# Altium schematic sheet 6_A9912FH-PCB2-BRIDGE_SR_MCU BOARD_V1.SchDoc("6_A9912FH-PCB2-BRIDGE_SR_MCU BOARD_V1")
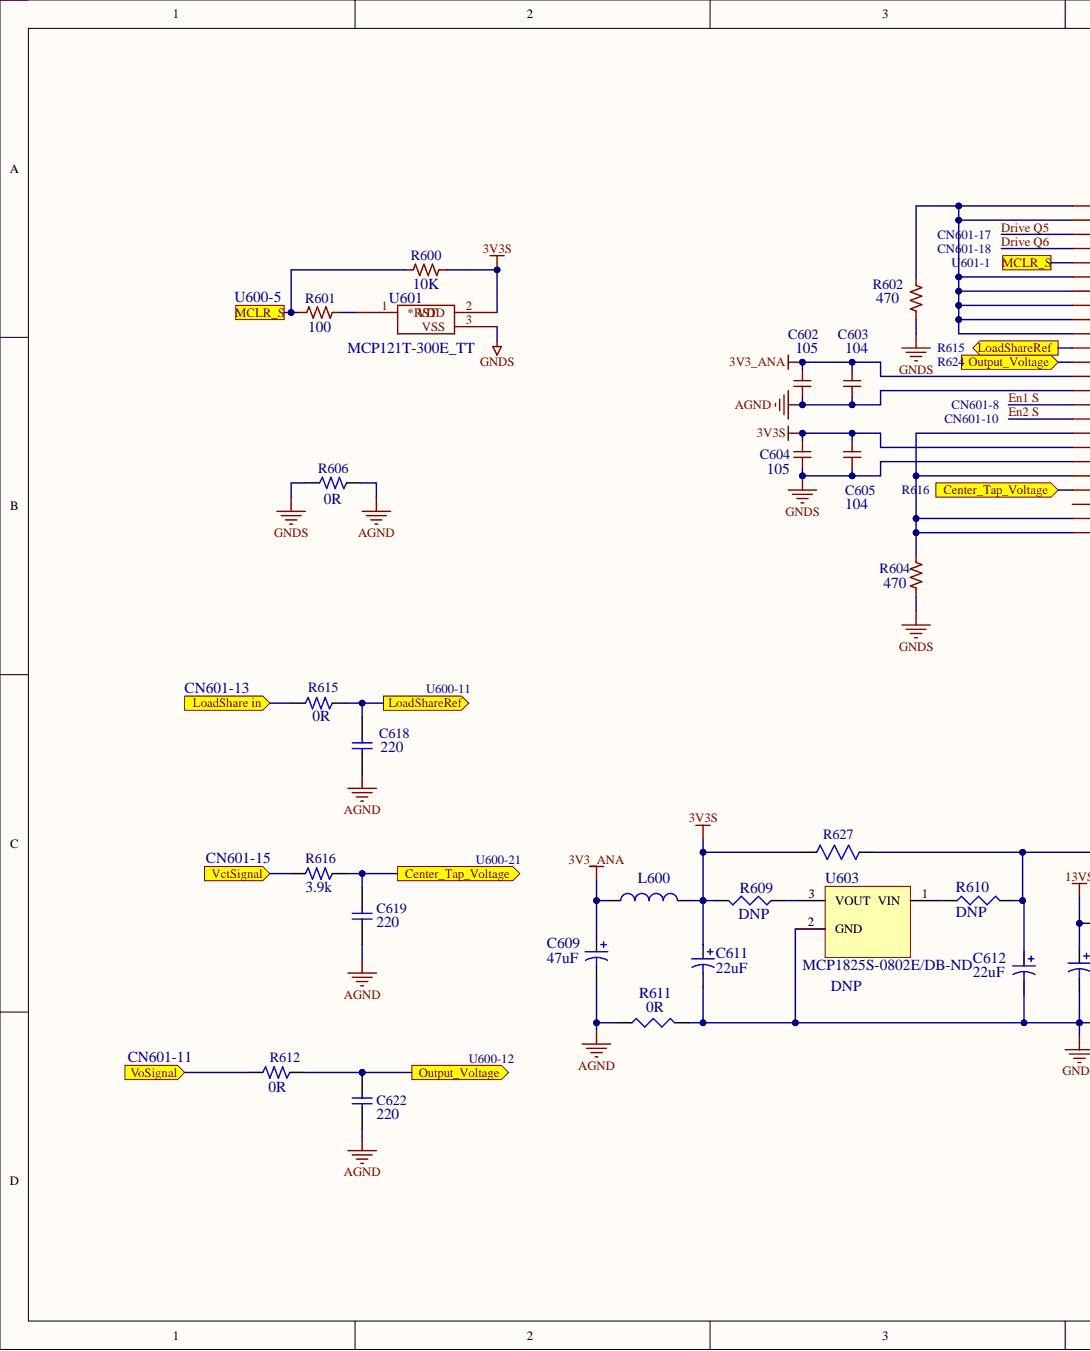
[diagram p0: 6_A9912FH-PCB2-BRIDGE_SR_MCU BOARD_V1.SchDoc, left]
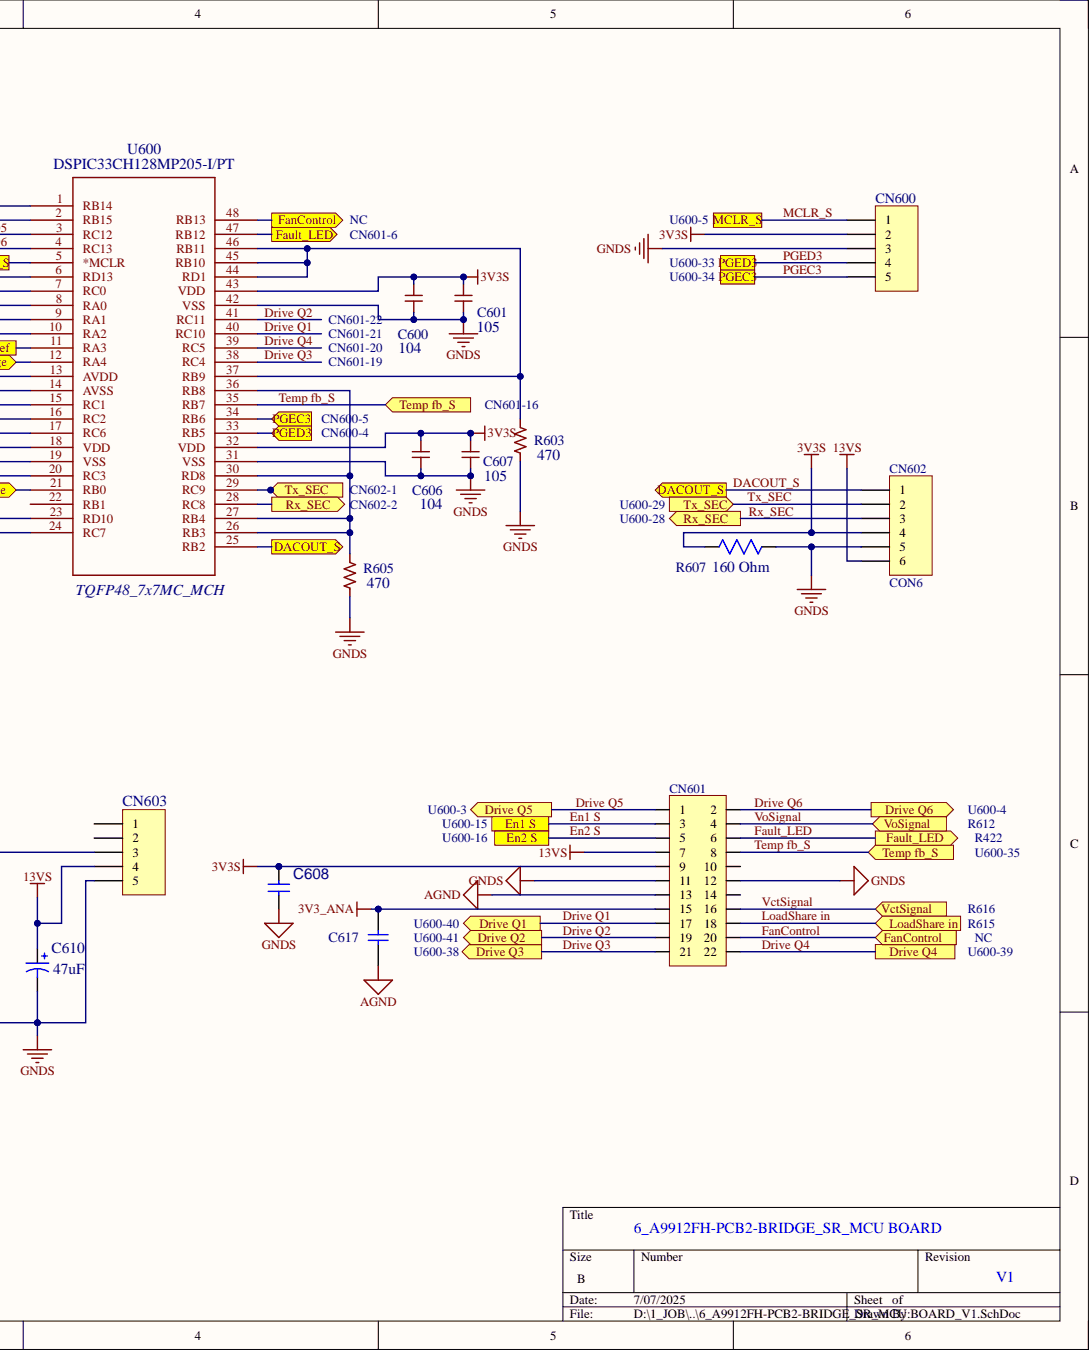
[diagram p1: 6_A9912FH-PCB2-BRIDGE_SR_MCU BOARD_V1.SchDoc, right]

## Parts
C600  value 104  (hidden comment: 1uF)
C601  value 105  (hidden comment: 0.1uF)
C602  value 105  (hidden comment: 0.1uF)
C603  value 104  (hidden comment: 1uF)
C604  value 105  (hidden comment: 0.1uF)
C605  value 104  (hidden comment: 1uF)
C606  value 104  (hidden comment: 1uF)
C607  value 105  (hidden comment: 0.1uF)
C608  0603_0.1uF_50V X7R  [CS-0805]  (value not drawn)
C609  value 47uF  [CE-5R-P2-I]  (hidden comment: Cap Pol3)
C610  value 47uF  [CE-5R-P2-I]  (hidden comment: Cap Pol3)
C611  value 22uF  [CE-5R-P2-I]  (hidden comment: Cap Pol3)
C612  value 22uF  [CE-5R-P2-I]  (hidden comment: Cap Pol3)
C617  Capacitor NP  [CS-0805]  (value not drawn)
C618  value 220  [CS-0805]  (hidden comment: Capacitor NP)
C619  value 220  [CS-0805]  (hidden comment: Capacitor NP)
C622  value 220  [CS-0805]  (hidden comment: Capacitor NP)
CN600  Header 6  [PN-P2_54-5]  (value not drawn)
CN601  CON22D  [CND-BH-P2_54-22H]  (value not drawn)
CN602  CON6  [PN-P2_54-6]
CN603  Header 6  [PN-P2_54-5]  (value not drawn)
L600  Inductor  [LS-0805]  (value not drawn)
R600  10K
R601  100
R602  470
R603  470
R604  470
R605  470
R606  value 0R  [RS-0805]  (hidden comment: Resistor)
R607  value 160 Ohm  [RS-0805]  (hidden comment: 2512_.001 Ohm)
R609  DNP  [RS-0805]
R610  DNP  [RS-0805]
R611  value 0R  [RS-0805]  (hidden comment: Res3)
R612  value 0R  [RS-0805]  (hidden comment: Resistor)
R615  value 0R  [RS-0805]  (hidden comment: Resistor)
R616  value 3.9k  [RS-0805]  (hidden comment: Resistor)
R627  (no value)  [RS-0805]
U600  DSPIC33CH128MP205-I/PT  [TQFP48_7x7MC_MCH]
U601  MCP121T-300E_TT  [SOT-23_MC_MCH]
U603  DNP  Supplier Part Number 1: MCP1825S-0802E/DB-ND  [IC-SOT223-123]

## Ports
Center_Tap_Voltage, DACOUT_S, Drive Q1, Drive Q2, Drive Q3, Drive Q4, Drive Q5, Drive Q6, En1 S, En2 S, FanControl, Fault_LED, LoadShare in, LoadShareRef, MCLR_S, Output_Voltage, PGEC3, PGED3, Rx_SEC, Temp fb_S, Tx_SEC, VctSignal, VoSignal

## Nets
3V3_ANA: C602.1 C603.1 C609.1 C617.2 CN601.15 L600.1 U600.13(AVDD)
3V3S: C600.1 C601.1 C604.1 C605.1 C606.1 C607.1 C608.1 C611.1 CN600.2 CN601.9 CN602.4 L600.2 R600.2 R607.1 R609.1 R627.1 U600.18(VDD) U600.32(VDD) U600.43(VDD) U601.2
13VS: C610.1 CN601.7 CN602.6 CN603.4
AGND: C602.2 C603.2 C609.2 C617.1 C618.1 C619.2 C622.1 CN601.13 R606.2 R611.2 U600.14(AVSS)
GNDS: C600.2 C601.2 C604.2 C605.2 C606.2 C607.2 C608.2 C610.2 C611.2 C612.2 CN600.3 CN601.11 CN601.12 CN602.5 CN603.5 R602.1 R603.1 R604.1 R605.1 R606.1 R607.2 R611.1 U600.19(VSS) U600.31(VSS) U600.42(VSS) U601.3 U603.2
Center_Tap_Voltage: C619.1 R616.2 U600.21(RB0) ; port Center_Tap_Voltage
DACOUT_S: CN602.1 U600.25(RB2) ; port DACOUT_S
Drive Q1: CN601.17 U600.40(RC10) ; port Drive Q1
Drive Q2: CN601.19 U600.41(RC11) ; port Drive Q2
Drive Q3: CN601.21 U600.38(RC4) ; port Drive Q3
Drive Q4: CN601.22 U600.39(RC5) ; port Drive Q4
Drive Q5: CN601.1 U600.3(RC12) ; port Drive Q5
Drive Q6: CN601.2 U600.4(RC13) ; port Drive Q6
En1 S: CN601.3 U600.15(RC1) ; port En1 S
En2 S: CN601.5 U600.16(RC2) ; port En2 S
FanControl: CN601.20 U600.48(RB13) ; port FanControl
Fault_LED: CN601.6 U600.47(RB12) ; port Fault_LED
LoadShare in: CN601.18 R615.1 ; port LoadShare in
LoadShareRef: C618.2 R615.2 U600.11(RA3) ; port LoadShareRef
MCLR_S: CN600.1 R600.1 R601.1 U600.5(*MCLR) ; port MCLR_S
Output_Voltage: C622.2 R612.2 U600.12(RA4) ; port Output_Voltage
PGEC3: CN600.5 U600.34(RB6) ; port PGEC3
PGED3: CN600.4 U600.33(RB5) ; port PGED3
Rx_SEC: CN602.3 U600.28(RC8) ; port Rx_SEC
Temp fb_S: CN601.8 U600.35(RB7) ; port Temp fb_S
Tx_SEC: CN602.2 U600.29(RC9) ; port Tx_SEC
VctSignal: CN601.16 R616.1 ; port VctSignal
VoSignal: CN601.4 R612.1 ; port VoSignal
NetC612_1: C612.1 CN603.3 R610.1 R627.2
NetR601_2: R601.2 U601.1
NetR602_2: R602.2 U600.1(RB14) U600.2(RB15) U600.6(RD13) U600.7(RC0) U600.8(RA0) U600.9(RA1) U600.10(RA2)
NetR603_2: R603.2 U600.37(RB9) U600.44(RD1) U600.45(RB10) U600.46(RB11)
NetR604_2: R604.2 U600.17(RC6) U600.20(RC3) U600.23(RD10) U600.24(RC7)
NetR605_2: R605.2 U600.26(RB3) U600.27(RB4) U600.30(RD8) U600.36(RB8)
NetR609_2: R609.2 U603.3
NetR610_2: R610.2 U603.1

Not connected: CN601 10 14; CN603 1 2; U600 22(RB1)

## Library data of the parts
C600-C607 = C_Small: Component Kind: Standard; Library Name: Device
C608 = 0603_0.1uF_50V X7R: CAP CER 4.7nF 50V 10% X7R 0603; Part Number: C1608X7R1H104K; Vendor: TDK Corporation; Component Kind: Standard; Digikey: 445-1314-1-ND; Library Name: Capacitors.IntLib; Mouser: 810-C1608X7R1H104K; Price: .012
C609-C612 = Cap Pol3: Polarized Capacitor (Surface Mount); Component Kind: Standard; LatestRevisionDate: 29-May-2009; LatestRevisionNote: IPC-7351 Footprint Added.; Library Name: Miscellaneous Devices.IntLib; PackageDescription: Chip Capacitor; PackageReference: C0805; Published: 8-Jun-2000; Signal Integrity: Cap Pol; Simulation: CAP; Publisher: Altium Limited
C617 = Capacitor NP: Capacitor; Description_: CAP CER 0.1uF 50V 10% X7R SMD 0603; Dev Tools Status: Production; MCHP-ID: CAP0581; Temperature Coeffcient: X7R; Tolerance: 10%; Voltage: 50V; Component Kind: Standard; ComponentLink1Description: SVN Datasheet; ComponentLink1URL: https://chn-vm-apps.microchip.com/mchprepo/parts/Datasheets/L0005E.pdf; ComponentLink2Description: Local Datasheet; ComponentLink3Description: Open Symbol; Package: 0603; Revision: 3; Status: MCL Design; Symbol: Capacitor NP; ComponentLink2URL: C:\ALTIUM_WORK\00001_MCHP-CDB\Datasheets\L0005E.pdf; ComponentLink3URL: C:\ALTIUM_WORK\00001_MCHP-CDB\Library\LibraryRefs\Capacitor NP.SchLib
C618 C622 = Capacitor NP: Capacitor; Description_: CAP CER 220pF 50V 10% X7R SMD 0603; Dev Tools Status: Production; MCHP-ID: CAP0618; Temperature Coeffcient: X7R; Tolerance: 10%; Voltage: 50V; Component Kind: Standard; ComponentLink1Description: SVN Datasheet; ComponentLink1URL: https://chn-vm-apps.microchip.com/mchprepo/parts/Datasheets/general_B11.pdf; ComponentLink2Description: Local Datasheet; Package: 0603; Revision: 1; Status: MCL Design; Symbol: Capacitor NP; ComponentLink2URL: C:\ALTIUM_WORK\00001_MCHP-CDB\Datasheets\general_B11.pdf; ComponentLink3URL: C:\ALTIUM_WORK\00001_MCHP-CDB\Library\LibraryRefs\Capacitor NP.SchLib
C619 = Capacitor NP: Capacitor; Description_: CAP CER 2200pF 50V 10% X7R SMD 0603; Dev Tools Status: Production; MCHP-ID: CAP0120; Temperature Coeffcient: X7R; Tolerance: 10%; Voltage: 50V; Component Kind: Standard; ComponentLink1Description: SVN Datasheet; ComponentLink1URL: https://chn-vm-apps.microchip.com/mchprepo/parts/Datasheets/ECJ__General_.pdf; ComponentLink2Description: Local Datasheet; Package: 0603; Revision: 1; Status: MCL Design; Symbol: Capacitor NP; ComponentLink2URL: C:\ALTIUM_WORK\00001_MCHP-CDB\Datasheets\ECJ__General_.pdf; ComponentLink3URL: C:\ALTIUM_WORK\00001_MCHP-CDB\Library\LibraryRefs\Capacitor NP.SchLib
CN600 CN603 = Header 5: Header, 5-Pin; Component Kind: Standard; LatestRevisionDate: 17-Jul-2002; LatestRevisionNote: Re-released for DXP Platform.; Library Name: Miscellaneous Connectors.IntLib; Signal Integrity: Connector; Publisher: Altium Limited
CN602 = CON6: Header, 6-Pin; Component Kind: Standard; LatestRevisionDate: 17-Jul-2002; LatestRevisionNote: Re-released for DXP Platform.; Library Name: CONNECT-SCH.SchLib; Publisher: Altium Limited
L600 = Inductor: Code_IEC: 1005; Value: ME322D-103KLB; Code_JEITA: 0402; Component Kind: Standard; Description: Inductor; LatestRevisionDate: 29-May-2009; LatestRevisionNote: IPC-7351 Footprint Added.; Library Name: Miscellaneous Devices.IntLib; PackageDescription: Chip Inductor; PackageReference: 0402-A; Published: 8-Jun-2000; Signal Integrity: Inductor; Simulation: INDUCTOR; Publisher: Altium Limited
R600-R605 = R_US: Component Kind: Standard; Library Name: Device
  R600: Value: 10K
  R601: Value: 100
  R602-R605: Value: 470
R606 R612 R615 = Resistor: Description_: RES TKF 0R 1/10W SMD 0603; Dev Tools Status: Production; MCHP-ID: RSMT0001; Component Kind: Standard; ComponentLink1Description: SVN Datasheet; ComponentLink1URL: https://chn-vm-apps.microchip.com/mchprepo/parts/Datasheets/nrc.pdf; ComponentLink2Description: Local Datasheet; ComponentLink3Description: Open Symbol; ComponentLink4Description: Open Footprint; Description: Resistor; Package: 0603; Revision: 2; Status: MCL Design; Symbol: Resistor; ComponentLink2URL: C:\ALTIUM_WORK\00001_MCHP-CDB\Datasheets\nrc.pdf; ComponentLink3URL: C:\ALTIUM_WORK\00001_MCHP-CDB\Library\LibraryRefs\Resistor.SchLib; ComponentLink4URL: C:\ALTIUM_WORK\00001_MCHP-CDB\Library\Footprints\RES_C_0603.PcbLib
R607 R627 = 2512_.001 Ohm: RES .001 OHM 1W 1% 2512 SMD; Part Number: ERJ-M1WTF1M0U; Vendor: Panasonic Electronic Components; Component Kind: Standard; Digikey: P1.0NDCT-ND; Library Name: Resistors.IntLib; Price: .1665
R609-R611 = Res3: Resistor; Component Kind: Standard; LatestRevisionDate: 29-May-2009; LatestRevisionNote: IPC-7351 Footprint Added.; Library Name: Miscellaneous Devices.IntLib; PackageDescription: Chip Resistor; PackageReference: RES0805; Published: 8-Jun-2000; Signal Integrity: Res; Simulation: RESISTOR; Publisher: Altium Limited
  R609 R610: Value: DNP
R616 = Resistor: Description_: RES TKF 3.9k 1% 1/10W SMD 0603; Dev Tools Status: Production; MCHP-ID: RSMT0690; Tolerance: 1%; Component Kind: Standard; ComponentLink1Description: SVN Datasheet; ComponentLink1URL: https://chn-vm-apps.microchip.com/mchprepo/parts/Datasheets/AOA0000CE2.pdf; ComponentLink2Description: Local Datasheet; ComponentLink3Description: Open Symbol; Description: Resistor; Package: 0603; Revision: 1; Status: MCL Design; Symbol: Resistor; ComponentLink2URL: C:\ALTIUM_WORK\00001_MCHP-CDB\Datasheets\AOA0000CE2.pdf; ComponentLink3URL: C:\ALTIUM_WORK\00001_MCHP-CDB\Library\LibraryRefs\Resistor.SchLib; ComponentLink4URL: C:\ALTIUM_WORK\00001_MCHP-CDB\Library\Footprints\RES_C_0603.PcbLib
U600 = DSPIC33CH128MP205-I_PT: Component Kind: Standard; Value: DSPIC33CH128MP205-I/PT; Library Name: amond_library
U603 = MCP1825S-0802E/DB: 500 mA, Low Voltage, Low Quiescent Current LDO Regulator, 3-Pin SOT-223, Extended Temperature; Component Kind: Standard; ComponentLink1Description: Datasheet; ComponentLink1URL: http://ww1.microchip.com/downloads/en/DeviceDoc/22056b.pdf; ComponentLink2Description: Packaging Specification; ComponentLink2URL: http://www.microchip.com/stellent/groups/techpub_sg/documents/packagingspec/en012702.pdf; ComponentLink3Description: Microchip.com Home; ComponentLink3URL: http://www.microchip.com/; DatasheetVersion: revB, Feb-2008; Library Name: Microchip Power Mgt Line Regulator.IntLib; PackageDescription: 3-Lead Plastic Small Outline Transistor (DB) [SOT-223]; PackageReference: SOT-223-DB3; PackageVersion: revBB, Aug-2009; Published: 29-Jan-2009; Supplier 1: Digi-Key; Publisher: Altium Limited
CN601 = CON22D: Component Kind: Standard; Library Name: CONNECT-SCH.SchLib
U601 = MCP121T-300E_TT: Component Kind: Standard; Value: MCP121T-300E_TT; Library Name: amond_library
